# Altium schematic sheet BrewTempController_5V.SchDoc
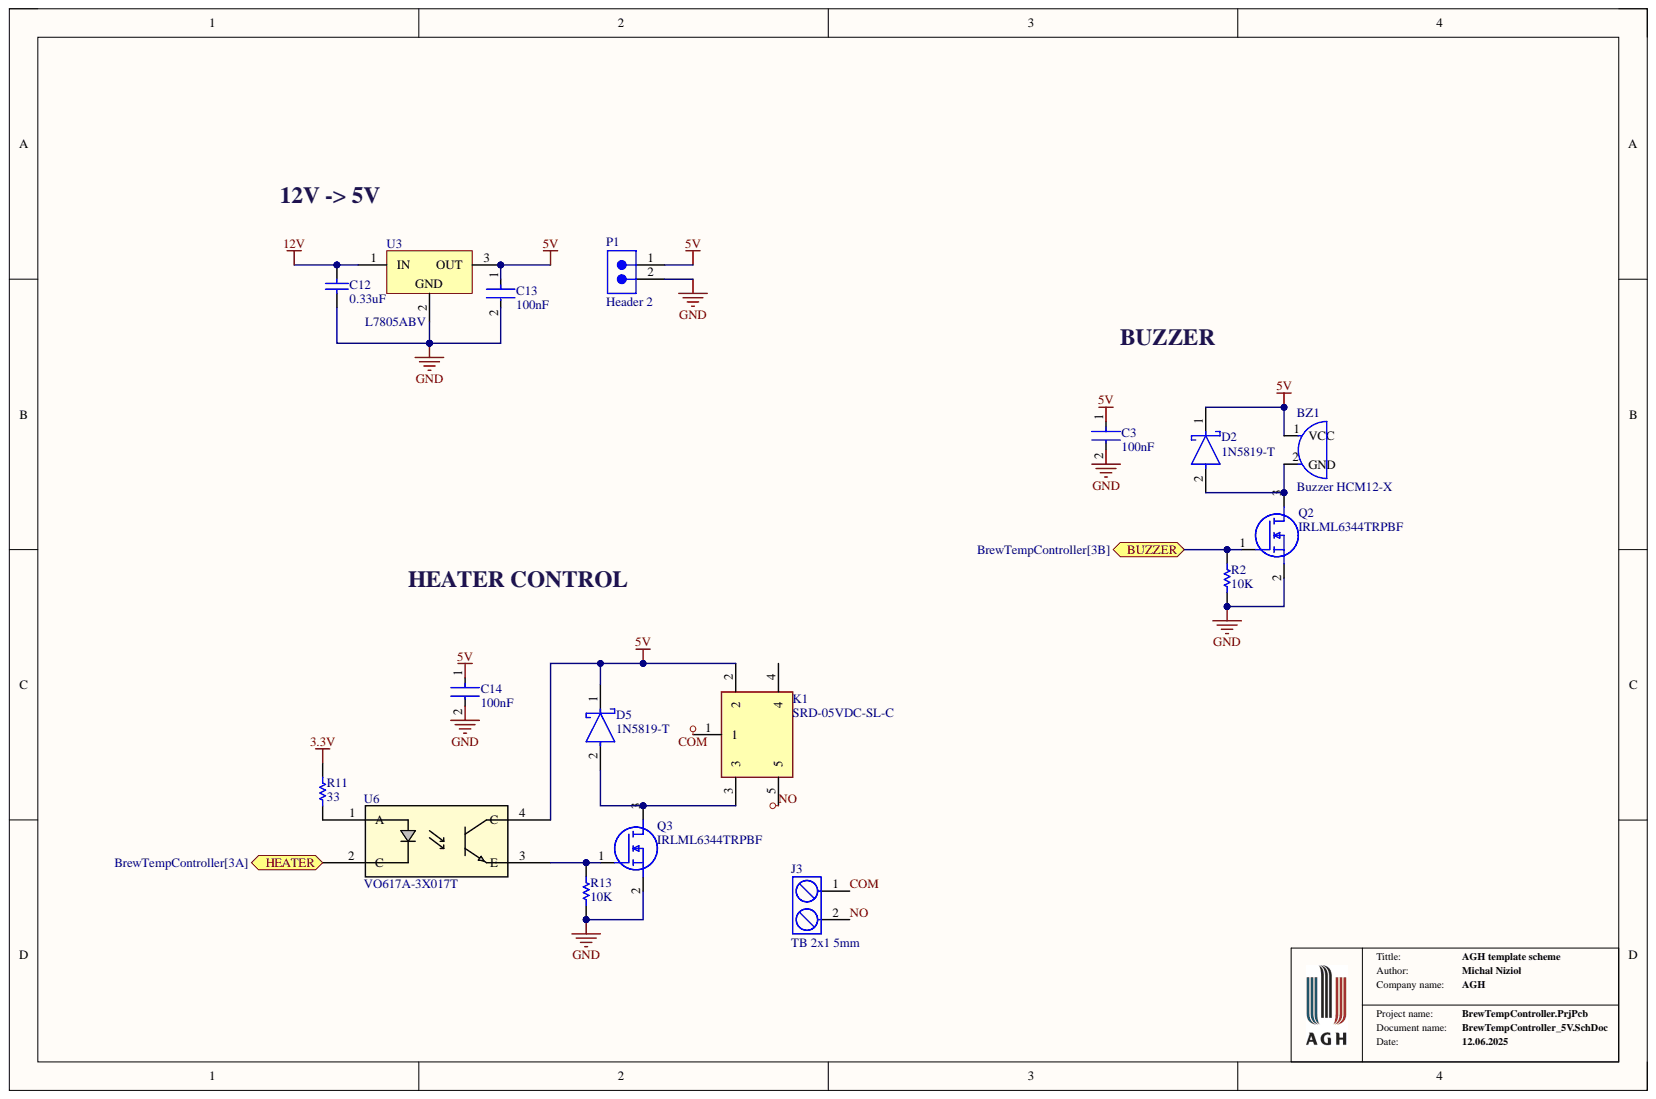
[diagram p0: BrewTempController_5V.SchDoc]

## Parts
BZ1  Buzzer HCM12-X  [HCM12-X]
C3  100nF  [FP-0805-L_2_01_0_2-W_1_25-MFG]
C12  0.33uF  [CAPC2013X140X50NL20T25]
C13  100nF  [FP-0805-L_2_01_0_2-W_1_25-MFG]
C14  100nF  [FP-0805-L_2_01_0_2-W_1_25-MFG]
D2  1N5819-T  [FP-DO-41_Plastic-MFG]
D5  1N5819-T  [FP-DO-41_Plastic-MFG]
J3  TB 2x1 5mm  [TB_2x1_5mm]
K1  SRD-05VDC-SL-C  [SRD]
P1  Header 2  [SMTC-TSW-102-26-XX-S]
Q2  IRLML6344TRPBF  [SOT95P237X112-3N]
Q3  IRLML6344TRPBF  [SOT95P237X112-3N]
R2  10K  [RESC2013X60X30NL20T20]
R11  33  [RESC2013X60X30LL20T20]
R13  10K  [RESC2013X60X30NL20T20]
U3  L7805ABV  [TO220]
U6  VO617A-3X017T  [SMD-4-OPT7_VO617A_VIS]

## Ports
BUZZER, HEATER

## Nets
3.3V: R11.2
5V: BZ1.1 C3.1 C13.1 C14.1 D2.1 D5.1 K1.2 P1.1 U3.3 U6.4(C)
12V: C12.2 U3.1
GND: C3.2 C12.1 C13.2 C14.2 P1.2 Q2.2 Q3.2 R2.1 R13.1 U3.2
BUZZER: Q2.1 R2.2 ; port BUZZER
COM: J3.1 K1.1
HEATER: U6.2(C) ; port HEATER
NO: J3.2 K1.5
NetBZ1_2: BZ1.2 D2.2 Q2.3
NetD5_2: D5.2 K1.3 Q3.3
NetQ3_1: Q3.1 R13.2 U6.3(E)
NetR11_1: R11.1 U6.1(A)

Not connected: K1 4

## Library data of the parts
BZ1 = HCM12-X: Buzzer with generator; Component Kind: Standard; Library Name: Schlib_AGH.SchLib
C3 C13 C14 = CMP-2007-04725-2: Automotive Ceramic Capacitor, 0805, 100nF, 5%, X7R, 15%, 50V; Dissipation Factor: 2.5%; Capacitance: 100nF; Case Code (Imperial): 0805; Case Code (Metric): 2012; Case/Package: 0805; Component Kind: Standard; Construction: Flat; Contact Plating: Tin; Depth: 1.25mm; Dielectric: X7R; Height: 0.94mm; Lead Free: Lead Free; Length: 2.01mm; Library Name: Altium Content Vault; Max Operating Temperature: 125°C; Min Operating Temperature: -55°C; Mount: Surface Mount; Number of Pins: 2; Package Quantity: 4000; Packaging: Tape and Reel; Radiation Hardening: No; Ratings: AEC-Q200; RoHS Compliant: Yes; Series: -; Termination: SMD/SMT; Thickness: 0.037inch; Tolerance: 5%; Voltage Rating: 50V; Voltage Rating (DC): 50V; Width: 0.049inch
C12 = CMP-1036-04599-2: 08053C334JAT2A; Manufacturer: AVX Interconnect / Elco; Component Kind: Standard; Library Name: Altium Content Vault; Part Number: 08053C334JAT2A
D2 D5 = CMP-12790-000042-1: DIODE SCHOTTKY 40V 1A DO41; Manufacturer: Diodes Inc.; Capacitance: 110 pF; Max Junction Temp: +125°C; Max Surge Forward Current: 25A; Peak Reverse Current: 1mA; Value: 110 pF; Automotive: No; Category: Diode; Component Kind: Standard; ComponentLink2Description: Datasheet; ComponentLink2URL: https://www.diodes.com/assets/Datasheets/ds23001.pdf; Device Class L1: Discrete Semiconductors; Device Class L2: Diodes; Device Class L3: Schottky Diodes; Digikey Description: DIODE SCHOTTKY 40V 1A DO41; Footprint Url: https://www.diodes.com/assets/Package-Files/DO-41-Plastic.pdf; Forward Current: 1A; Height: 2.72mm; Ipc Land Pattern Name: DIOPA1091W105L521D272; Lead Free: Yes; Library Name: Altium Content Vault; Manufacturer Part Number: 1N5819-T; Max Repetitive Reverse Voltage: 40V; Mouser Description: Schottky Diodes & Rectifiers Vr/40V Io/1A T/R; Octopart: https://octopart.com/1n5819-t-diodes+inc.-55389586; Package: DO-41 (Plastic); Reverse Voltage: 40V; RoHS: TRUE; Temperature: -65°C to +125°C; Temperature Range High: +125°C; Temperature Range Low: -65°C
J3 = TB_2x1_5mm: Terminal block (ARK connector) 2x1 5mm; Component Kind: Standard; Library Name: Schlib_AGH.SchLib
K1 = SRD-05VDC-SL-C: Relay or Contactor; Manufacturer_Name: Songle Relay; Component Kind: Standard; Datasheet Link: http://files.microjpm.webnode.com/200001010-dda41de9e1/Songle%20Relay%20Datasheet.pdf; Manufacturer_Part_Number: SRD-05VDC-SL-C
P1 = CMP-1024-00329-1: 0.025" SQ Post Header, Through-hole, Vertical, -55 to 125 degC, 2.54 mm Pitch, 2-Pin, Male, RoHS; Manufacturer: Samtec; PartNumber: TSW-102-26-F-S; Pitch: 2.54 mm; Component Kind: Standard; ComponentLink1Description: Manufacturer URL; ComponentLink1URL: http://www.samtec.com; ComponentLink2Description: Datasheet; ComponentLink2URL: http://www.samtec.com/documents/webfiles/pdf/TSW_TH.PDF; ComponentLink3Description: Package Specification; ComponentLink3URL: http://www.samtec.com/documents/webfiles/cpdf/TSW-XXX-XX-XXX-X-XX-XXX-MKT.pdf; DatasheetVersion: F-214; Library Name: Altium Content Vault; Mounting Technology: Through-hole; Orientation: Vertical; PackageDescription: .025[0.64] SQ. Post Terminal Strip Assembly; PackageReference: TSW-102-26-XX-S; PackageVersion: DM, 8/1988; RoHS: True; Temperature Range: -55 to 125 degC
Q2 Q3 = IRLML6344TRPBF: MOSFET (N-Channel); Manufacturer_Name: Infineon; Arrow Part Number: IRLML6344TRPBF; Arrow Price/Stock: https://www.arrow.com/en/products/irlml6344trpbf/infineon-technologies-ag?utm_currency=USD&region=nac; Component Kind: Standard; Datasheet Link: https://www.infineon.com/dgdl/irlml6344pbf.pdf?fileId=5546d462533600a4015356689c44262c; Height: 1.12mm; Manufacturer_Part_Number: IRLML6344TRPBF; Mouser Part Number: 942-IRLML6344TRPBF; Mouser Price/Stock: https://www.mouser.co.uk/ProductDetail/Infineon-Technologies/IRLML6344TRPBF?qs=9%252BKlkBgLFf2w4qS48UOXVw%3D%3D
R2 R13 = CMP-2001-04657-1: Manufacturer: KOA Speer; Part Number: RK73B2ATTD103J; Component Kind: Standard; Library Name: Altium Content Vault
R11 = CMP-2001-01819-1: RK73B2ATTD330J; Manufacturer: KOA Speer; Component Kind: Standard; Library Name: Altium Content Vault; Part Number: RK73B2ATTD330J
U3 = CMP-0244-00485-1: Positive Voltage Regulator, 5V, 3-Pin TO-220; Component Kind: Standard; ComponentLink1Description: Manufacturer URL; ComponentLink1URL: http://www.st.com; ComponentLink2Description: Datasheet; ComponentLink2URL: http://www.st.com/internet/com/TECHNICAL_RESOURCES/TECHNICAL_LITERATURE/DATASHEET/CD00000444.pdf; ComponentLink3Description: Package Specification; ComponentLink3URL: http://www.st.com/internet/com/TECHNICAL_RESOURCES/TECHNICAL_LITERATURE/PACKAGE_INFORMATION/CD00004649.pdf; DatasheetVersion: 16/11/2011; Library Name: Altium Content Vault; PackageDescription: TO, Thru-Hole, Vertical, Heatsink Mounted; 3 In-Line Leads; Pitch 2.54 mm; PackageReference: TO220; PackageVersion: ver3.0
U6 = CMP-00030-45022644-1: VO617A-3X017T Optocoupler Dc-in 1-CH Transistor Dc-out 4-PIN PDIP SMD T/r; Collector Emitter Saturation Voltage: 400mV; Collector Emitter Voltage (VCEO): 80V; Current Transfer Ratio: 200%; Forward Current: 60mA; Forward Voltage: 1.65V; Isolation Voltage: 5.3kV; Max Collector Current: 50mA; Max Power Dissipation: 150mW; Number of Channels: 1; Reverse Breakdown Voltage: 6V; Case/Package: SMD/SMT; Component Kind: Standard; Input Current: 60mA; Lead Free: Lead Free; Library Name: Altium Content Vault; Max Input Current: 60mA; Max Operating Temperature: 110°C; Max Output Voltage: 80V; Min Operating Temperature: -55°C; Mount: Surface Mount; NexarPartId: 45022644; Number of Elements: 1; Number of Pins: 4; Output Current per Channel: 50mA; Output Voltage: 80V; Packaging: Tape & Reel; Power Dissipation: 150mW; Radiation Hardening: No; REACH SVHC: Unknown; RoHS: Compliant; Schedule B: 8541408000
